# Altium schematic sheet 06_ADC sample.SchDoc
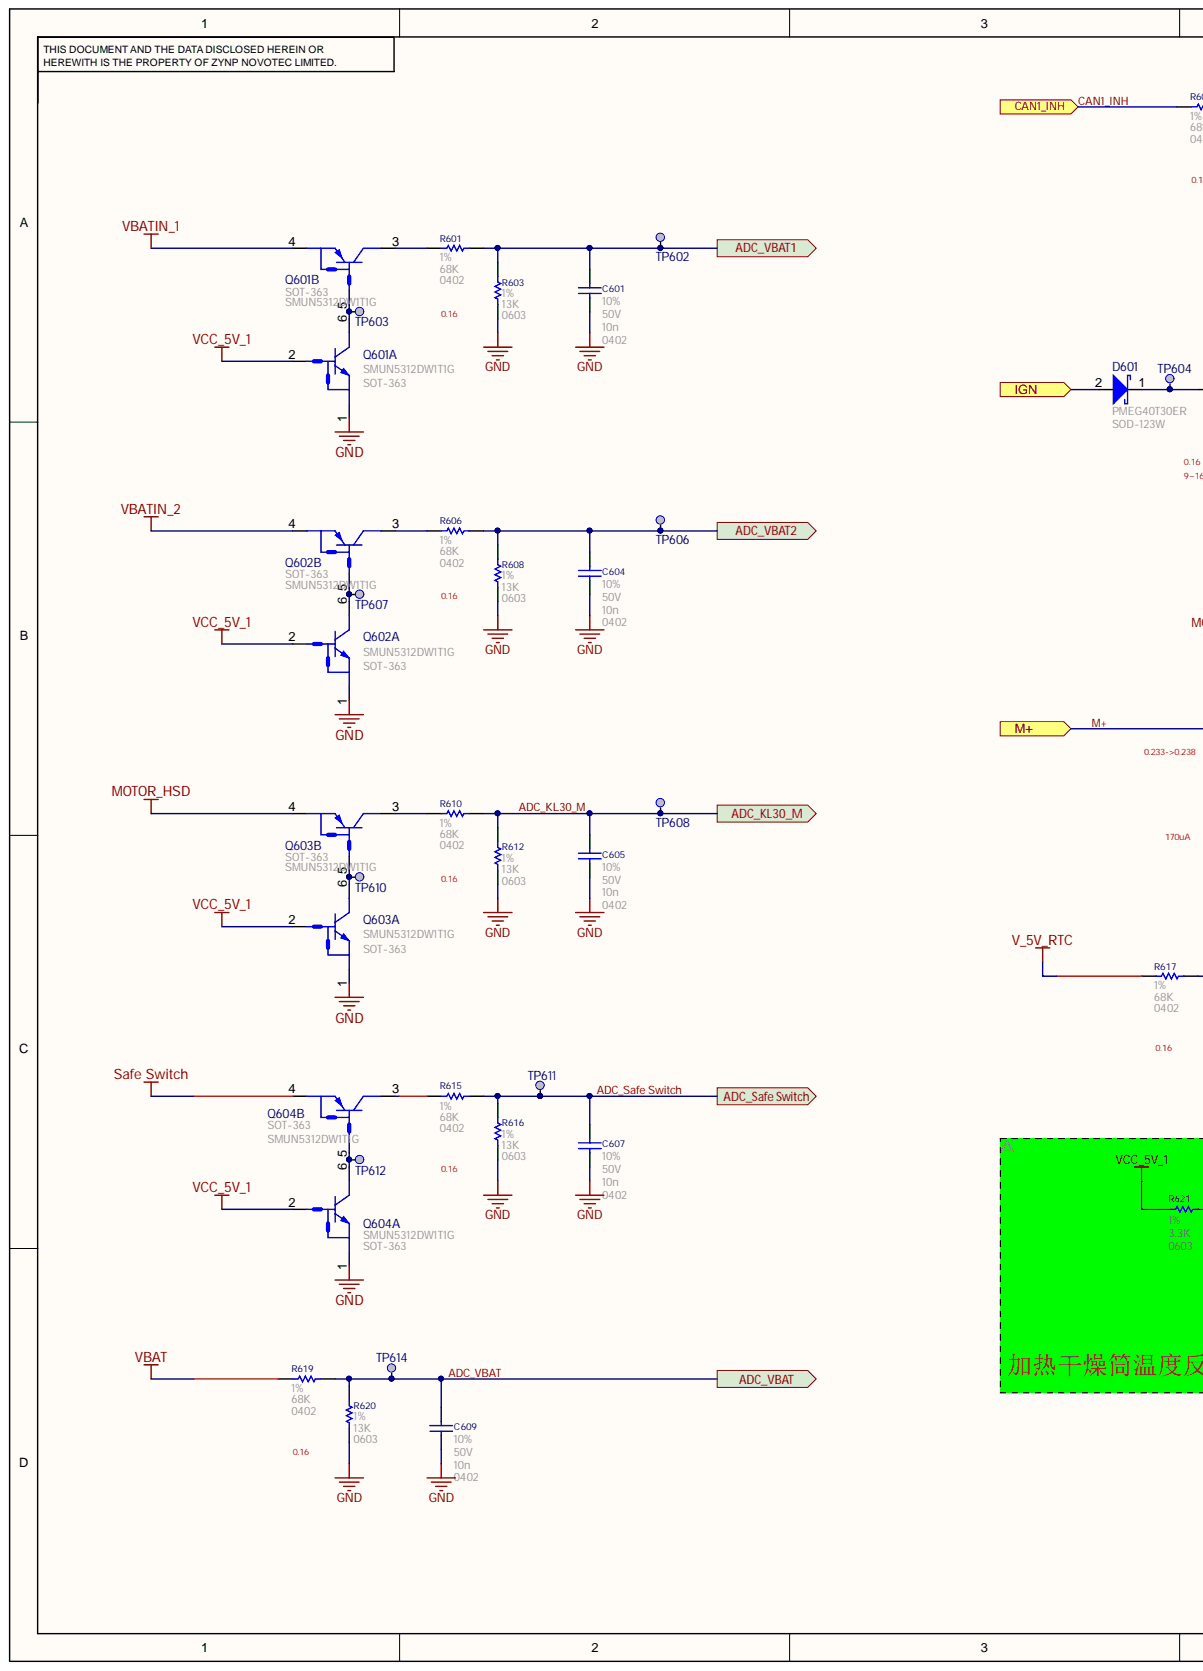
[diagram p0: 06_ADC sample.SchDoc, left]
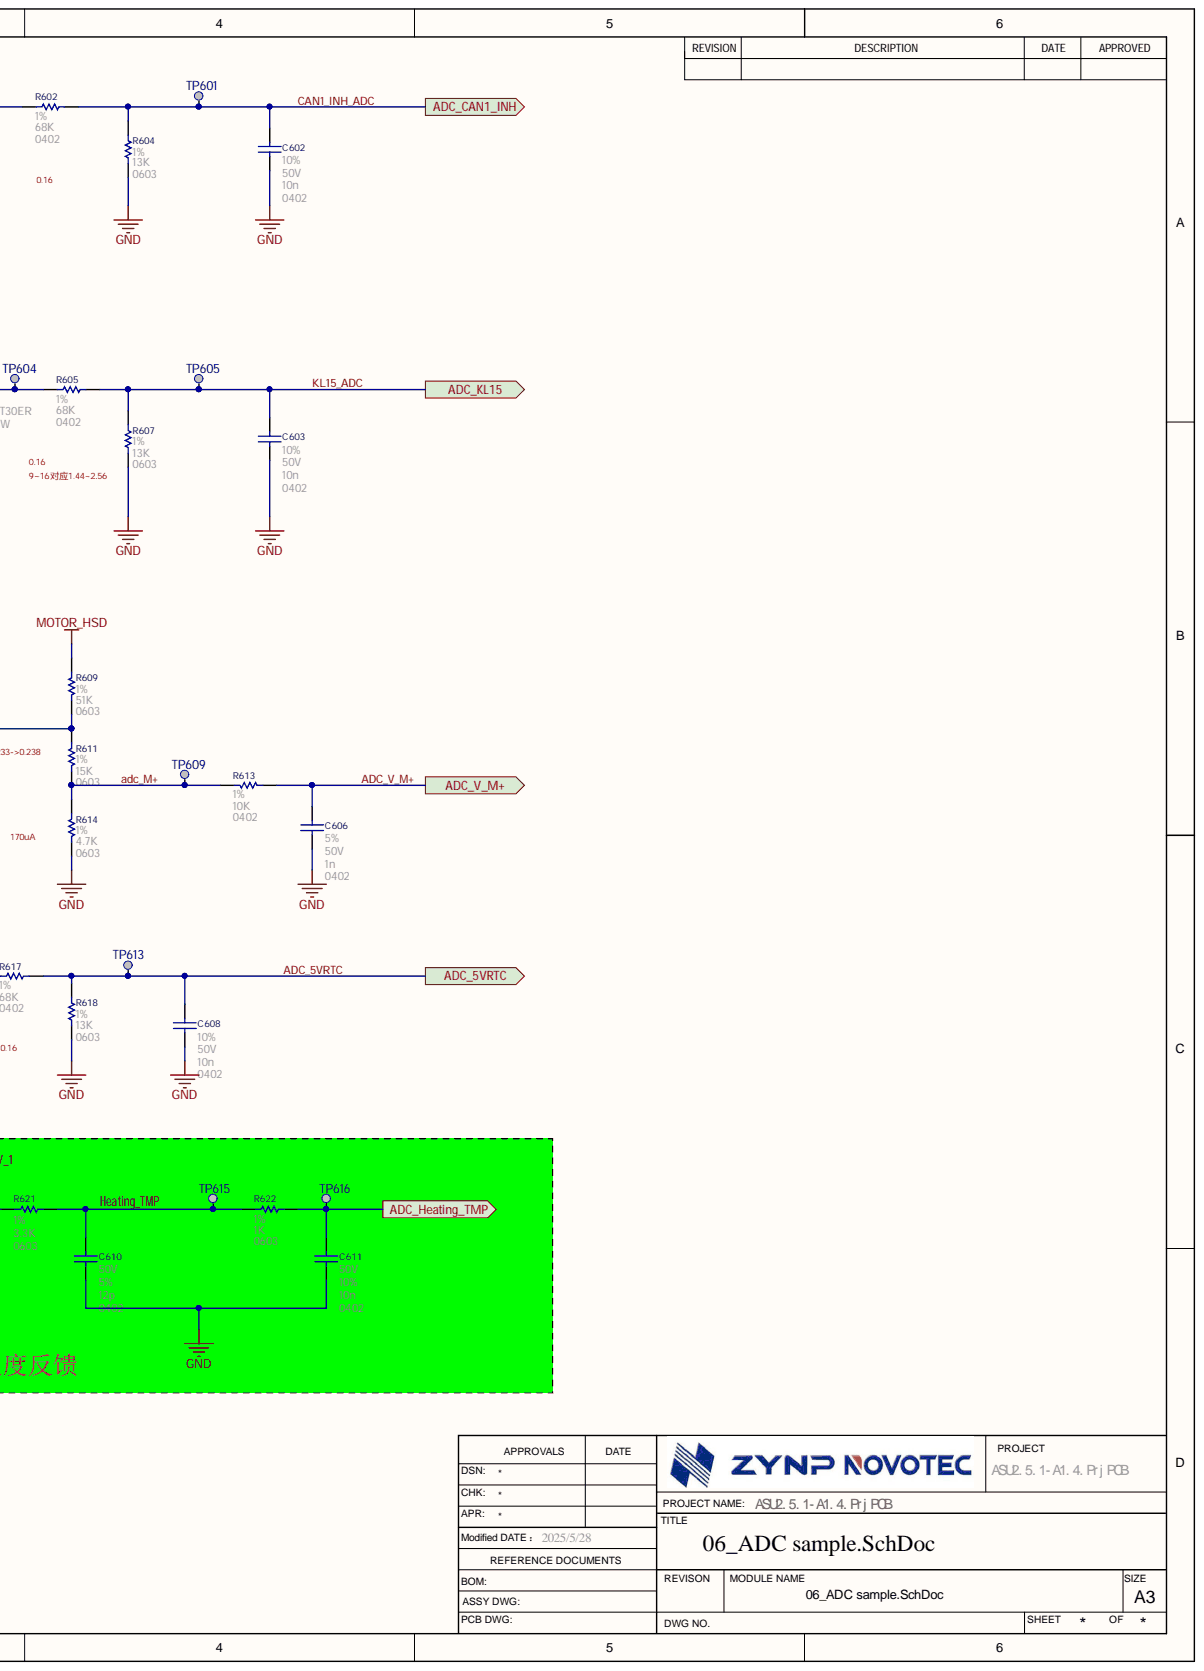
[diagram p1: 06_ADC sample.SchDoc, right]

## Parts
C601  value 10n  Package: 0402  Tolerance: 10%  Voltage: 50V  [C0402]  (hidden comment: CAP)
C602  value 10n  Package: 0402  Tolerance: 10%  Voltage: 50V  [C0402]  (hidden comment: CAP)
C603  value 10n  Package: 0402  Tolerance: 10%  Voltage: 50V  [C0402]  (hidden comment: CAP)
C604  value 10n  Package: 0402  Tolerance: 10%  Voltage: 50V  [C0402]  (hidden comment: CAP)
C605  value 10n  Package: 0402  Tolerance: 10%  Voltage: 50V  [C0402]  (hidden comment: CAP)
C606  value 1n  Package: 0402  Tolerance: 5%  Voltage: 50V  [C0402]  (hidden comment: CAP)
C607  value 10n  Package: 0402  Tolerance: 10%  Voltage: 50V  [C0402]  (hidden comment: CAP)
C608  value 10n  Package: 0402  Tolerance: 10%  Voltage: 50V  [C0402]  (hidden comment: CAP)
C609  value 10n  Package: 0402  Tolerance: 10%  Voltage: 50V  [C0402]  (hidden comment: CAP)
C610  value 12p  Package: 0402  Tolerance: 5%  Voltage: 50V  [C0402]  (hidden comment: CAP)
C611  value 10n  Package: 0402  Tolerance: 10%  Voltage: 50V  [C0402]  (hidden comment: CAP)
D601  Part_number: PMEG40T30ER  [SOD-123W]  (hidden comment: Diode)
Q601  value SMUN5312DW1T1G  Part_number: SMUN5312DW1T1G  [SOT-363]  (hidden comment: Q_SMUN5312DW1T1G)  (parts A,B)
Q602  value SMUN5312DW1T1G  Part_number: SMUN5312DW1T1G  [SOT-363]  (hidden comment: Q_SMUN5312DW1T1G)  (parts A,B)
Q603  value SMUN5312DW1T1G  Part_number: SMUN5312DW1T1G  [SOT-363]  (hidden comment: Q_SMUN5312DW1T1G)  (parts A,B)
Q604  value SMUN5312DW1T1G  Part_number: SMUN5312DW1T1G  [SOT-363]  (hidden comment: Q_SMUN5312DW1T1G)  (parts A,B)
R601  value 68K  Package: 0402  Tolerance: 1%  [R0402]  (hidden comment: Res)
R602  value 68K  Package: 0402  Tolerance: 1%  [R0402]  (hidden comment: Res)
R603  value 13K  Package: 0603  Tolerance: 1%  [R0603]  (hidden comment: Res)
R604  value 13K  Package: 0603  Tolerance: 1%  [R0603]  (hidden comment: Res)
R605  value 68K  Package: 0402  Tolerance: 1%  [R0402]  (hidden comment: Res)
R606  value 68K  Package: 0402  Tolerance: 1%  [R0402]  (hidden comment: Res)
R607  value 13K  Package: 0603  Tolerance: 1%  [R0603]  (hidden comment: Res)
R608  value 13K  Package: 0603  Tolerance: 1%  [R0603]  (hidden comment: Res)
R609  value 51K  Package: 0603  Tolerance: 1%  [R0603]  (hidden comment: Res)
R610  value 68K  Package: 0402  Tolerance: 1%  [R0402]  (hidden comment: Res)
R611  value 15K  Package: 0603  Tolerance: 1%  [R0603]  (hidden comment: Res)
R612  value 13K  Package: 0603  Tolerance: 1%  [R0603]  (hidden comment: Res)
R613  value 10K  Package: 0402  Tolerance: 1%  [R0402]  (hidden comment: Res)
R614  value 4.7K  Package: 0603  Tolerance: 1%  [R0603]  (hidden comment: Res)
R615  value 68K  Package: 0402  Tolerance: 1%  [R0402]  (hidden comment: Res)
R616  value 13K  Package: 0603  Tolerance: 1%  [R0603]  (hidden comment: Res)
R617  value 68K  Package: 0402  Tolerance: 1%  [R0402]  (hidden comment: Res)
R618  value 13K  Package: 0603  Tolerance: 1%  [R0603]  (hidden comment: Res)
R619  value 68K  Package: 0402  Tolerance: 1%  [R0402]  (hidden comment: Res)
R620  value 13K  Package: 0603  Tolerance: 1%  [R0603]  (hidden comment: Res)
R621  value 3.3K  Package: 0603  Tolerance: 1%  [R0603]  (hidden comment: Res)
R622  value 1K  Package: 0603  Tolerance: 1%  [R0603]  (hidden comment: Res)
TP601  TEST Point  [TP-0.9]  (value not drawn)
TP602  TEST Point  [TP-0.9]  (value not drawn)
TP603  TEST Point  [TP-0.9]  (value not drawn)
TP604  TEST Point  [TP-0.9]  (value not drawn)
TP605  TEST Point  [TP-0.9]  (value not drawn)
TP606  TEST Point  [TP-0.9]  (value not drawn)
TP607  TEST Point  [TP-0.9]  (value not drawn)
TP608  TEST Point  [TP-0.9]  (value not drawn)
TP609  TEST Point  [TP-0.9]  (value not drawn)
TP610  TEST Point  [TP-0.9]  (value not drawn)
TP611  TEST Point  [TP-0.9]  (value not drawn)
TP612  TEST Point  [TP-0.9]  (value not drawn)
TP613  TEST Point  [TP-0.9]  (value not drawn)
TP614  TEST Point  [TP-0.9]  (value not drawn)
TP615  TEST Point  [TP-0.9]  (value not drawn)
TP616  TEST Point  [TP-0.9]  (value not drawn)

## Ports
ADC_5VRTC, ADC_CAN1_INH, ADC_Heating_TMP, ADC_KL15, ADC_KL30_M, ADC_Safe Switch, ADC_V_M+, ADC_VBAT, ADC_VBAT1, ADC_VBAT2, CAN1_INH, IGN, M+

## Nets
GND: C601.1 C602.1 C603.1 C604.1 C605.1 C606.1 C607.1 C608.1 C609.1 C610.1 C611.1 Q601.1 Q602.1 Q603.1 Q604.1 R603.1 R604.1 R607.1 R608.1 R612.1 R614.1 R616.1 R618.1 R620.1
MOTOR_HSD: Q603.4 R609.2
Safe Switch: Q604.4
V_5V_RTC: R617.1
VBAT: R619.1
VBATIN_1: Q601.4
VBATIN_2: Q602.4
VCC_5V_1: Q601.2 Q602.2 Q603.2 Q604.2 R621.2
ADC_5VRTC: C608.2 R617.2 R618.2 TP613.1 ; port ADC_5VRTC
ADC_Heating_TMP: C611.2 R622.2 TP616.1 ; port ADC_Heating_TMP
ADC_KL30_M: C605.2 R610.2 R612.2 TP608.1 ; port ADC_KL30_M
adc_M+: R611.1 R613.1 R614.2 TP609.1
ADC_Safe Switch: C607.2 R615.2 R616.2 TP611.1 ; port ADC_Safe Switch
ADC_V_M+: C606.2 R613.2 ; port ADC_V_M+
ADC_VBAT: C609.2 R619.2 R620.2 TP614.1 ; port ADC_VBAT
ADC_VBAT1: C601.2 R601.2 R603.2 TP602.1 ; port ADC_VBAT1
ADC_VBAT2: C604.2 R606.2 R608.2 TP606.1 ; port ADC_VBAT2
CAN1_INH: R602.1 ; port CAN1_INH
CAN1_INH_ADC: C602.2 R602.2 R604.2 TP601.1 ; port ADC_CAN1_INH
Heating_TMP: C610.2 R621.1 R622.1 TP615.1
IGN: D601.2 ; port IGN
KL15_ADC: C603.2 R605.2 R607.2 TP605.1 ; port ADC_KL15
M+: R609.1 R611.2 ; port M+
NetD601_1: D601.1 R605.1 TP604.1
NetQ601_3: Q601.3 R601.1
NetQ601_5: Q601.5 Q601.6 TP603.1
NetQ602_3: Q602.3 R606.1
NetQ602_5: Q602.5 Q602.6 TP607.1
NetQ603_3: Q603.3 R610.1
NetQ603_5: Q603.5 Q603.6 TP610.1
NetQ604_3: Q604.3 R615.1
NetQ604_5: Q604.5 Q604.6 TP612.1

## Library data of the parts
C601-C605 C607-C609 C611 = C_10n_50V_10%_GCM155R71H103KA55D: 112000097; Manufacturer: Murata; Location: Japan; Regulation: GCM155R71H103KA55D、Murata、10n、10%、50V、X7R、-55℃~125℃、0402; SAP_number: 10052234; Specification: RoHS AEC-Q200; Temperature_char: X7R; Type: 陶瓷电容; Component Kind: Standard; Library Name: RLC.SchLib; Part_number: GCM155R71H103KA55D; TC_number: 112000097; Temperature: -55℃~125℃
C606 = C_1n_50V_5%_GCM1555G1H102JA16D: 112000067; Manufacturer: Murata; Location: Japan; Regulation: GCM1555G1H102JA16D、Murata、1n、5%、50V、X8G、-55℃~150℃、0402; SAP_number: 10056779; Specification: RoHS AEC-Q200; Temperature_char: X8G; Type: 陶瓷电容; Component Kind: Standard; Library Name: RLC.SchLib; Part_number: GCM1555G1H102JA16D; TC_number: 112000067; Temperature: -55℃~150℃
C610 = C_12p_50V_5%_GCM1555C1H120JA16D: Manufacturer: Murata; Location: Japan; Regulation: GCM1555C1H120JA16D、Murata、12p、±5%、50V、C0G、-55℃~125℃、0402; Specification: RoHS AEC-Q200; Temperature_char: C0G; Type: 陶瓷电容; Component Kind: Standard; Library Name: RLC.SchLib; Part_number: GCM1555C1H120JA16D; Temperature: -55℃~125℃
D601 = D_40V_PMEG40T30ER: Manufacturer: Nexperia; Forward Current(If): 3A; Peak Forward Current(Ifrm): 4.2A; Regulation: PMEG40T30ER、Nexperia、3A、40V、-65℃~175℃、SOD-123W; Reverse Voltage(Vr): 40V; Specification: RoHS AEC-Q101; type: 肖特基二极管; Component Kind: Standard; Library Name: Diode.SchLib; Package: SOD-123W; Peak Forward Surge Current(Ifsm): 4.2A; Temperature: -65℃-175℃
Q601-Q604 = Q_SMUN5312DW1T1G: 114000001; Manufacturer: Onsemi; Channel_number: 2; Channel_type: NPN+PNP; Ic: 100mA; Regulation: SMUN5312DW1T1G、Onsemi、1PNP+1NPN、100mA、0.25V、-55℃~150℃、SOT363; SAP_number: 10052259; Specification: RoHS AEC-Q101; Type: 三极管; Vcbo: 50V; Vebo: 6V; Component Kind: Standard; Library Name: Transistor.SchLib; Package: SOT-363; TC_number: 114000001; Temperature: -55℃~150℃; Vceo: 50V
R601 R602 R605 R606 R610 R615 R617 R619 = R_68K_1/16W_1%_AC0402FR-0768KL: AC0402FR-0768KL、YAGEO、68K、1%、0402; Manufacturer: YAGEO; Location: taiwan; Power: 1/16W; Specification: RoHS AEC-Q200; Component Kind: Standard; Library Name: RLC.SchLib; Part_number: AC0402FR-0768KL; Regulation: AC0402FR-0768KL、YAGEO、68K、1%、0402; Temperature: -55℃～155℃; Type: 厚膜电阻
R603 R604 R607 R608 R612 R616 R618 R620 = R_13K_1/10W_1%_AC0603FR-0713KL: Manufacturer: YAGEO; Location: taiwan; Power: 1/10W; Regulation: AC0603FR-0713KL、YAGEO、13K、1%、0603; Specification: RoHS AEC-Q200; Component Kind: Standard; Library Name: RLC.SchLib; Part_number: AC0603FR-0713KL; Temperature: -55℃～155℃; Type: 厚膜电阻
R609 = R_51K_1/10W_1%_AC0603FR-0751KL: AC0603FR-0751KL、YAGEO、51K、1%、0603; Manufacturer: YAGEO; Location: taiwan; Power: 1/10W; Specification: RoHS AEC-Q200; Component Kind: Standard; Library Name: RLC.SchLib; Part_number: AC0603FR-0751KL; Regulation: AC0603FR-0751KL、YAGEO、51K、1%、0603; Temperature: -55℃～155℃; Type: 厚膜电阻
R611 = R_15K_1/10W_1%_AC0603FR-0715KL: Manufacturer: YAGEO; Location: taiwan; Power: 1/10W; Regulation: AC0603FR-0715KL、YAGEO、15K、1%、0603; Specification: RoHS AEC-Q200; Component Kind: Standard; Library Name: RLC.SchLib; Part_number: AC0603FR-0715KL; Temperature: -55℃～155℃; Type: 厚膜电阻
R613 = R_10K_1/16W_1%_AC0402FR-0710KL: Manufacturer: YAGEO; Location: taiwan; Power: 1/16W; Regulation: AC0402FR-0710KL、YAGEO、10K、1%、0402; Specification: RoHS AEC-Q200; Component Kind: Standard; Library Name: RLC.SchLib; Part_number: AC0402FR-0710KL; Temperature: -55℃～155℃; Type: 厚膜电阻
R614 = R_4.7K_1/10W_1%_AC0603FR-074K7L: AC0603FR-074K7L、YAGEO、4.7K、1%、0603; Manufacturer: YAGEO; Location: taiwan; Power: 1/10W; Specification: RoHS AEC-Q200; Component Kind: Standard; Library Name: RLC.SchLib; Part_number: AC0603FR-074K7L; Regulation: AC0603FR-074K7L、YAGEO、4.7K、1%、0603; Temperature: -55℃～155℃; Type: 厚膜电阻
R621 = R_3.3K_1/10W_1%_AC0603FR-073K3L: AC0603FR-073K3L、YAGEO、3.3K、1%、0603; Manufacturer: YAGEO; Location: taiwan; Power: 1/10W; Specification: RoHS AEC-Q200; Component Kind: Standard; Library Name: RLC.SchLib; Part_number: AC0603FR-073K3L; Regulation: AC0603FR-073K3L、YAGEO、3.3K、1%、0603; Temperature: -55℃～155℃; Type: 厚膜电阻
R622 = R_1K_1/10W_1%_AC0603FR-071KL: Manufacturer: YAGEO; Location: taiwan; Power: 1/10W; Regulation: AC0603FR-071KL、YAGEO、1K、1%、0603; Specification: RoHS AEC-Q200; Component Kind: Standard; Library Name: RLC.SchLib; Part_number: AC0603FR-071KL; Temperature: -55℃～155℃; Type: 厚膜电阻
TP601-TP616 = TEST_PIN_0.9: TP; Component Kind: Standard; Library Name: Header.SchLib; Package: TP-0.9; Type: test pin; Value: TEST
